# Altium schematic sheet Sheet3.SchDoc(Sheet3)
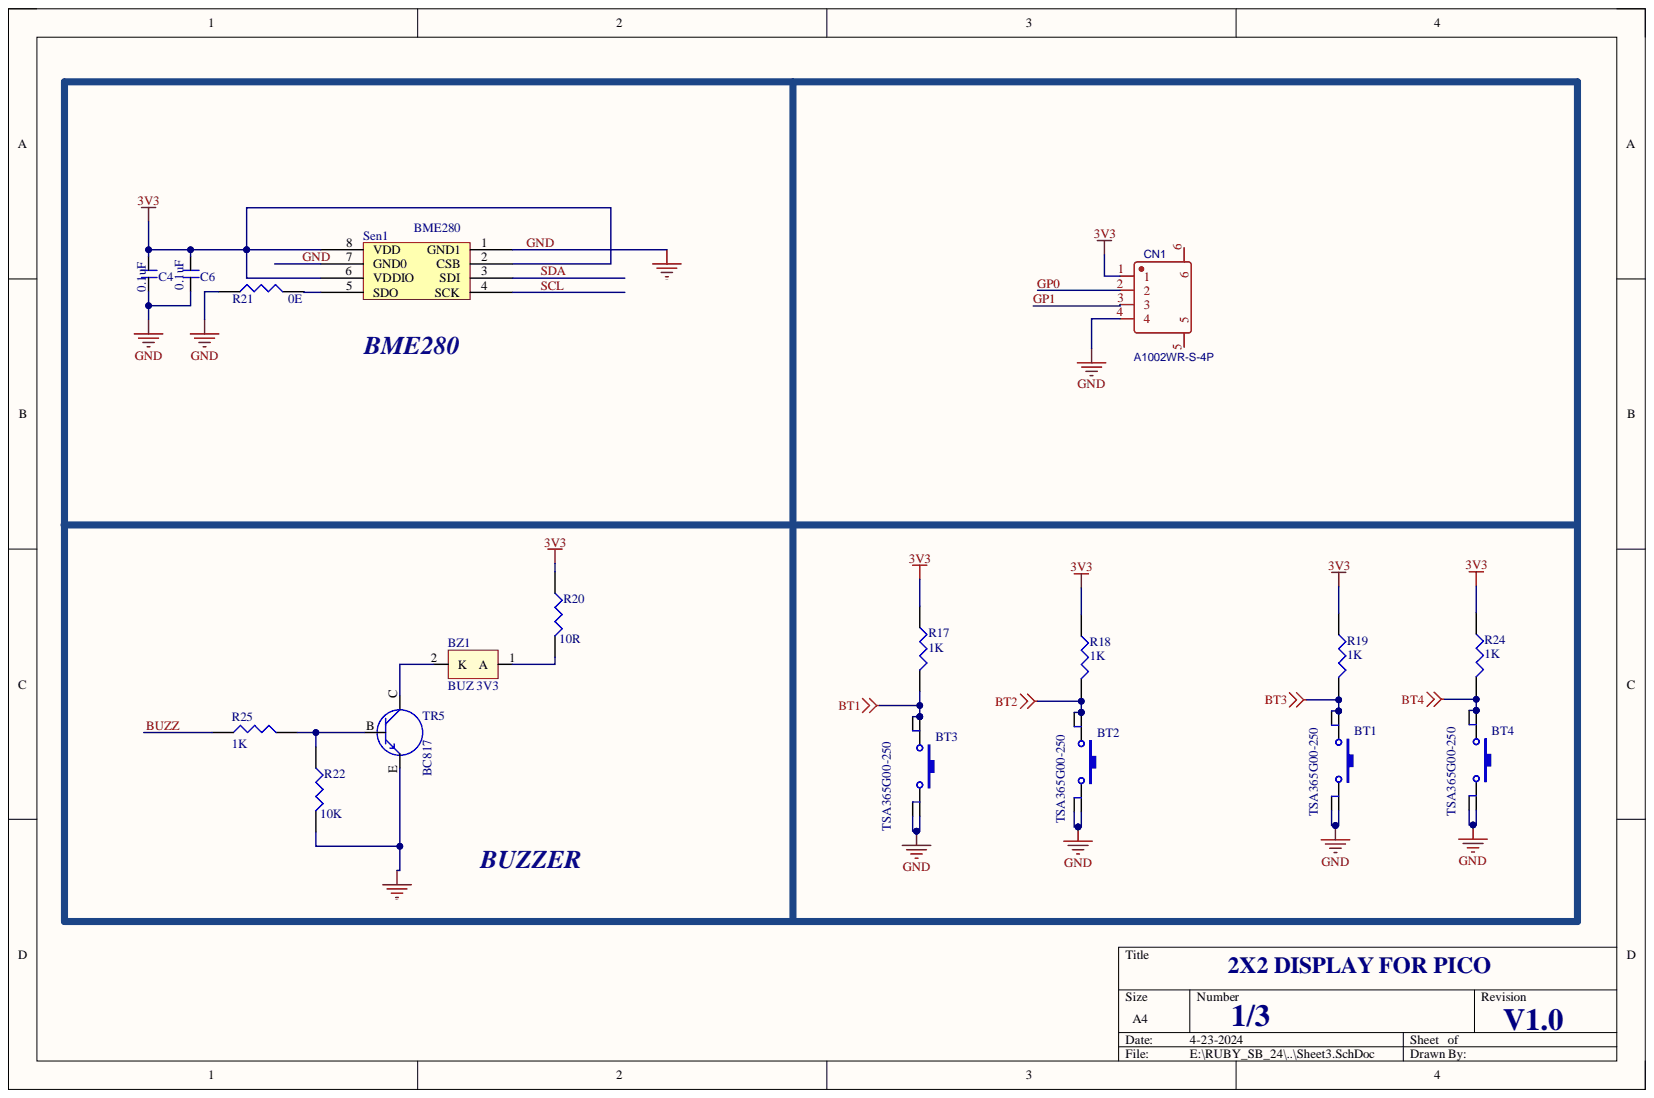
[diagram p0: Sheet3.SchDoc]

## Parts
BT1  TSA365G00-250  [TACT_]
BT2  TSA365G00-250  [TACT_]
BT3  TSA365G00-250  [TACT_]
BT4  TSA365G00-250  [TACT_]
BZ1  BUZ 3V3  [SMD BUZZ]
C4  0.1uF  [C_0603]
C6  0.1uF  [C_0603]
CN1  A1002WR-S-4P  [SH 1x4P 1mm]
R17  1K  [R_0603]
R18  1K  [R_0603]
R19  1K  [R_0603]
R20  10R  [R_0603]
R21  0E  [R_0603]
R22  10K  [R_0603]
R24  1K  [R_0603]
R25  1K  [R_0603]
Sen1  BME280  [BME28]
TR5  BC817  [SOT23]

## Nets
3V3: C4.2 C6.2 CN1.1 R17.1 R18.1 R19.1 R20.1 R24.1 Sen1.2(CSB) Sen1.6(VDDIO) Sen1.8(VDD)
BT1: BT3.1 BT3.2 R17.2
BT2: BT2.1 BT2.2 R18.2
BT3: BT1.1 BT1.2 R19.2
BT4: BT4.1 BT4.2 R24.2
BUZZ: R25.1
GND: BT1.3 BT1.4 BT2.3 BT2.4 BT3.3 BT3.4 BT4.3 BT4.4 C4.1 C6.1 CN1.4 R21.1 R22.2 Sen1.1(GND1) Sen1.7(GND0) TR5.E
GP0: CN1.2
GP1: CN1.3
SCL: Sen1.4(SCK)
SDA: Sen1.3(SDI)
NetBZ1_1: BZ1.1 R20.2
NetBZ1_2: BZ1.2 TR5.C
NetR21_2: R21.2 Sen1.5(SDO)
NetR22_1: R22.1 R25.2 TR5.B

Not connected: CN1 5 6

## Library data of the parts
BT1-BT4 = TACTILE_SWITCH: Component Kind: Standard; Library Name: dxp_pasl_lib.IntLib
BZ1 = Buzzer: Component Kind: Standard; Library Name: dxp_pasl_lib.IntLib
C4 C6 = CAP_NONPOLAR: Component Kind: Standard; Library Name: dxp_pasl_lib.IntLib
CN1 = A1002WR-S-4P: Manufacturer: CJT(长江连接器); Contributor: LCSC; Component Kind: Standard; JLCPCB Part Class: Extended Part; Manufacturer Part: A1002WR-S-4P; spicePre: C; spiceSymbolName: A1002WR-S-4P; Supplier: LCSC; Supplier Part: C239522
R17-R22 R24 R25 = RES: Component Kind: Standard; Library Name: dxp_pasl_lib.IntLib
Sen1 = BME280: Digital humidity, pressure and temperature sensor 2.5mm X 2.5mm X 0.93mm metal lid LGA; Component Kind: Standard; Library Name: dxp_pasl_lib.IntLib
TR5 = TRANSISTER_NPN: Component Kind: Standard; Library Name: dxp_pasl_lib.IntLib
